# Altium schematic sheet CONNECTOR_EXP.SchDoc(CONNECTOR_EXP)
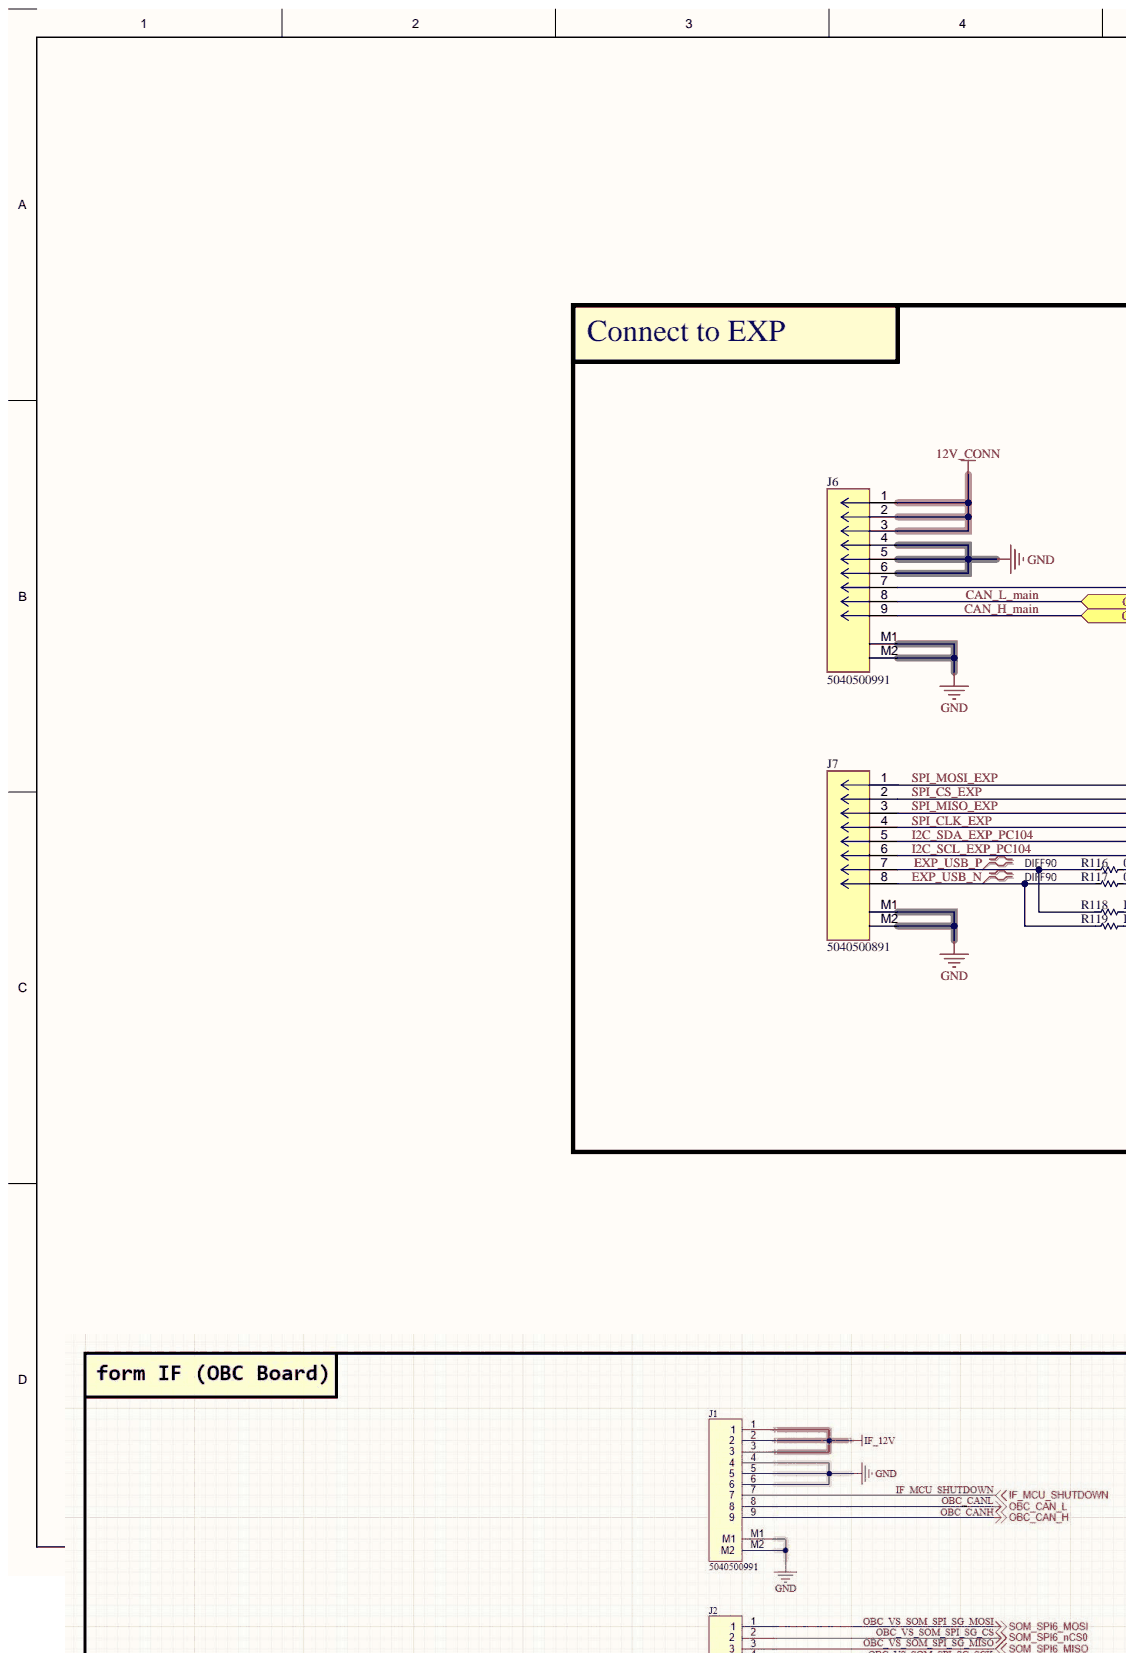
[diagram p0: CONNECTOR_EXP.SchDoc, left]
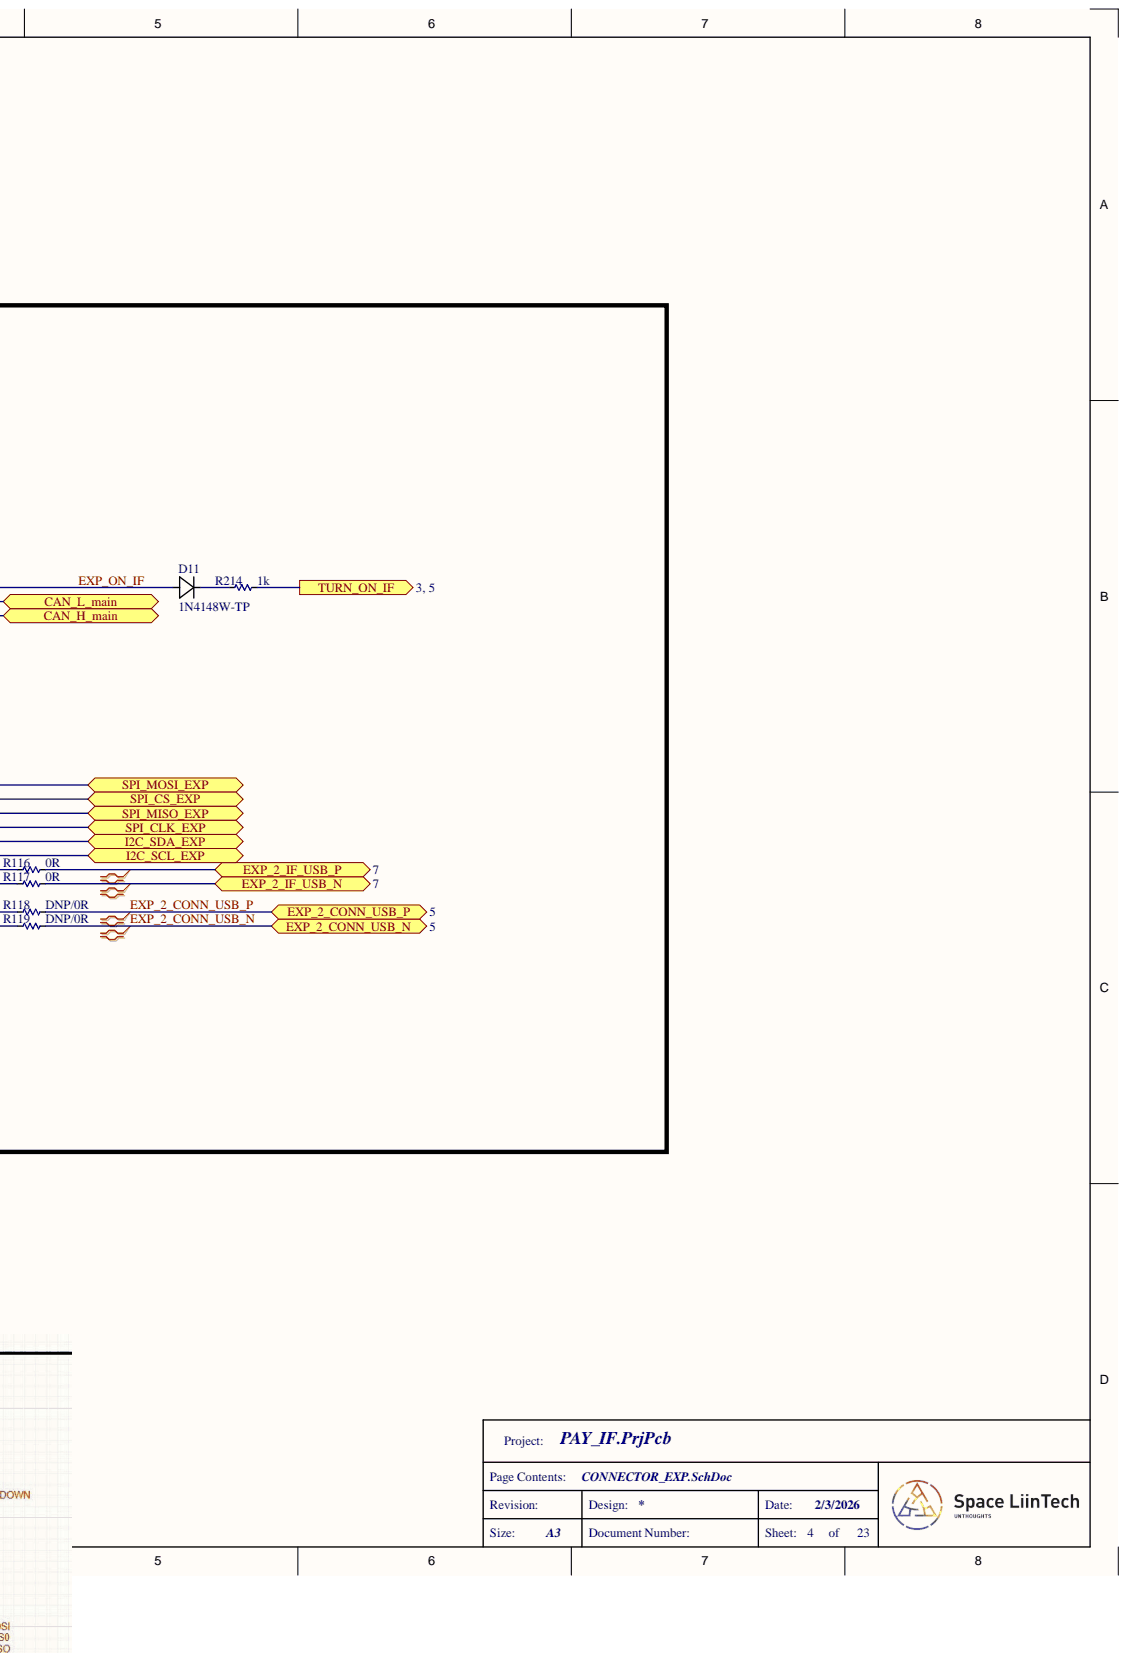
[diagram p1: CONNECTOR_EXP.SchDoc, right]

## Parts
D11  1N4148W-TP  [SOD123_MCC]
J6  5040500991  [MOLEX_5040500991]
J7  5040500891  [MOLEX_5040500891]
R116  0R  [RES_C_0603X30]
R117  0R  [RES_C_0603X30]
R118  DNP/0R  [RES_C_0603X30]
R119  DNP/0R  [RES_C_0603X30]
R214  1k  [RES_C_1005x30_L]

## Ports
CAN_H_main, CAN_L_main, EXP_2_CONN_USB_N, EXP_2_CONN_USB_P, EXP_2_IF_USB_N, EXP_2_IF_USB_P, I2C_SCL_EXP, I2C_SDA_EXP, SPI_CLK_EXP, SPI_CS_EXP, SPI_MISO_EXP, SPI_MOSI_EXP, TURN_ON_IF

## Nets
12V_CONN: J6.1 J6.2 J6.3
GND: J6.4 J6.5 J6.6 J6.M1 J6.M2 J7.M1 J7.M2
CAN_H_main: J6.9 ; port CAN_H_main
CAN_L_main: J6.8 ; port CAN_L_main
EXP_2_CONN_USB_N: R119.2 ; port EXP_2_CONN_USB_N
EXP_2_CONN_USB_P: R118.2 ; port EXP_2_CONN_USB_P
EXP_2_IF_USB_N: R117.2 ; port EXP_2_IF_USB_N
EXP_2_IF_USB_P: R116.2 ; port EXP_2_IF_USB_P
EXP_ON_IF: D11.2 J6.7
EXP_USB_N: J7.8 R117.1 R119.1
EXP_USB_P: J7.7 R116.1 R118.1
I2C_SCL_EXP_PC104: J7.6 ; port I2C_SCL_EXP
I2C_SDA_EXP_PC104: J7.5 ; port I2C_SDA_EXP
SPI_CLK_EXP: J7.4 ; port SPI_CLK_EXP
SPI_CS_EXP: J7.2 ; port SPI_CS_EXP
SPI_MISO_EXP: J7.3 ; port SPI_MISO_EXP
SPI_MOSI_EXP: J7.1 ; port SPI_MOSI_EXP
TURN_ON_IF: R214.2 ; port TURN_ON_IF
NetD11_1: D11.1 R214.1

## Library data of the parts
D11 = 1N4148W-TP: Description; Manufacturer_Name: MCC; Component Kind: Standard; Manufacturer_Part_Number: 1N4148W-TP; Copyright: Copyright (C) 2026 Ultra Librarian. All rights reserved.; Library Name: IFBschelib.SchLib
J6 = 5040500991: Connector Header Surface Mount, Right Angle 9 position 0.059" (1.50mm); Component Kind: Standard; Library Name: IFBschelib.SchLib
J7 = 5040500891: Connector Header Surface Mount, Right Angle 8 position 0.059" (1.50mm)`; Component Kind: Standard; Library Name: IFBschelib.SchLib
R116-R119 R214 = _RES_B_2: RES; Component Kind: Standard; Library Name: IFBschelib.SchLib
